# Altium schematic sheet Stepper Motor3.SchDoc
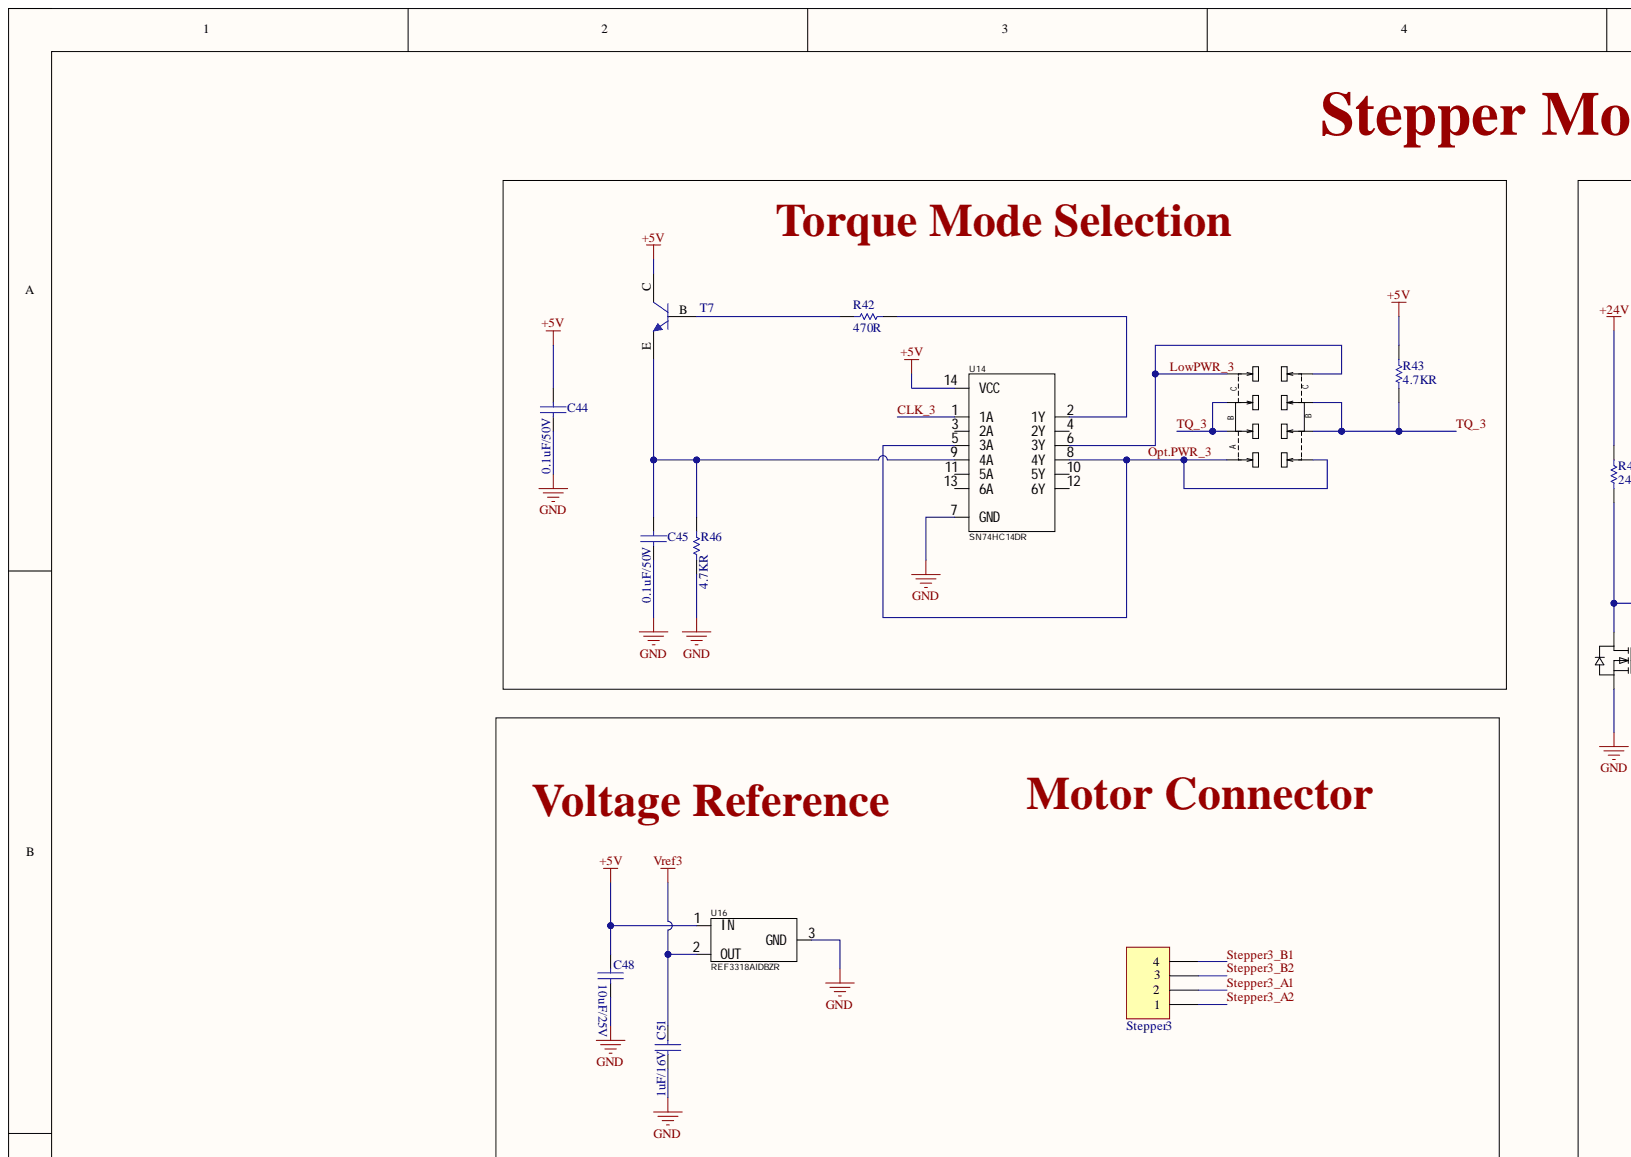
[diagram p0: Stepper Motor3.SchDoc, top left]
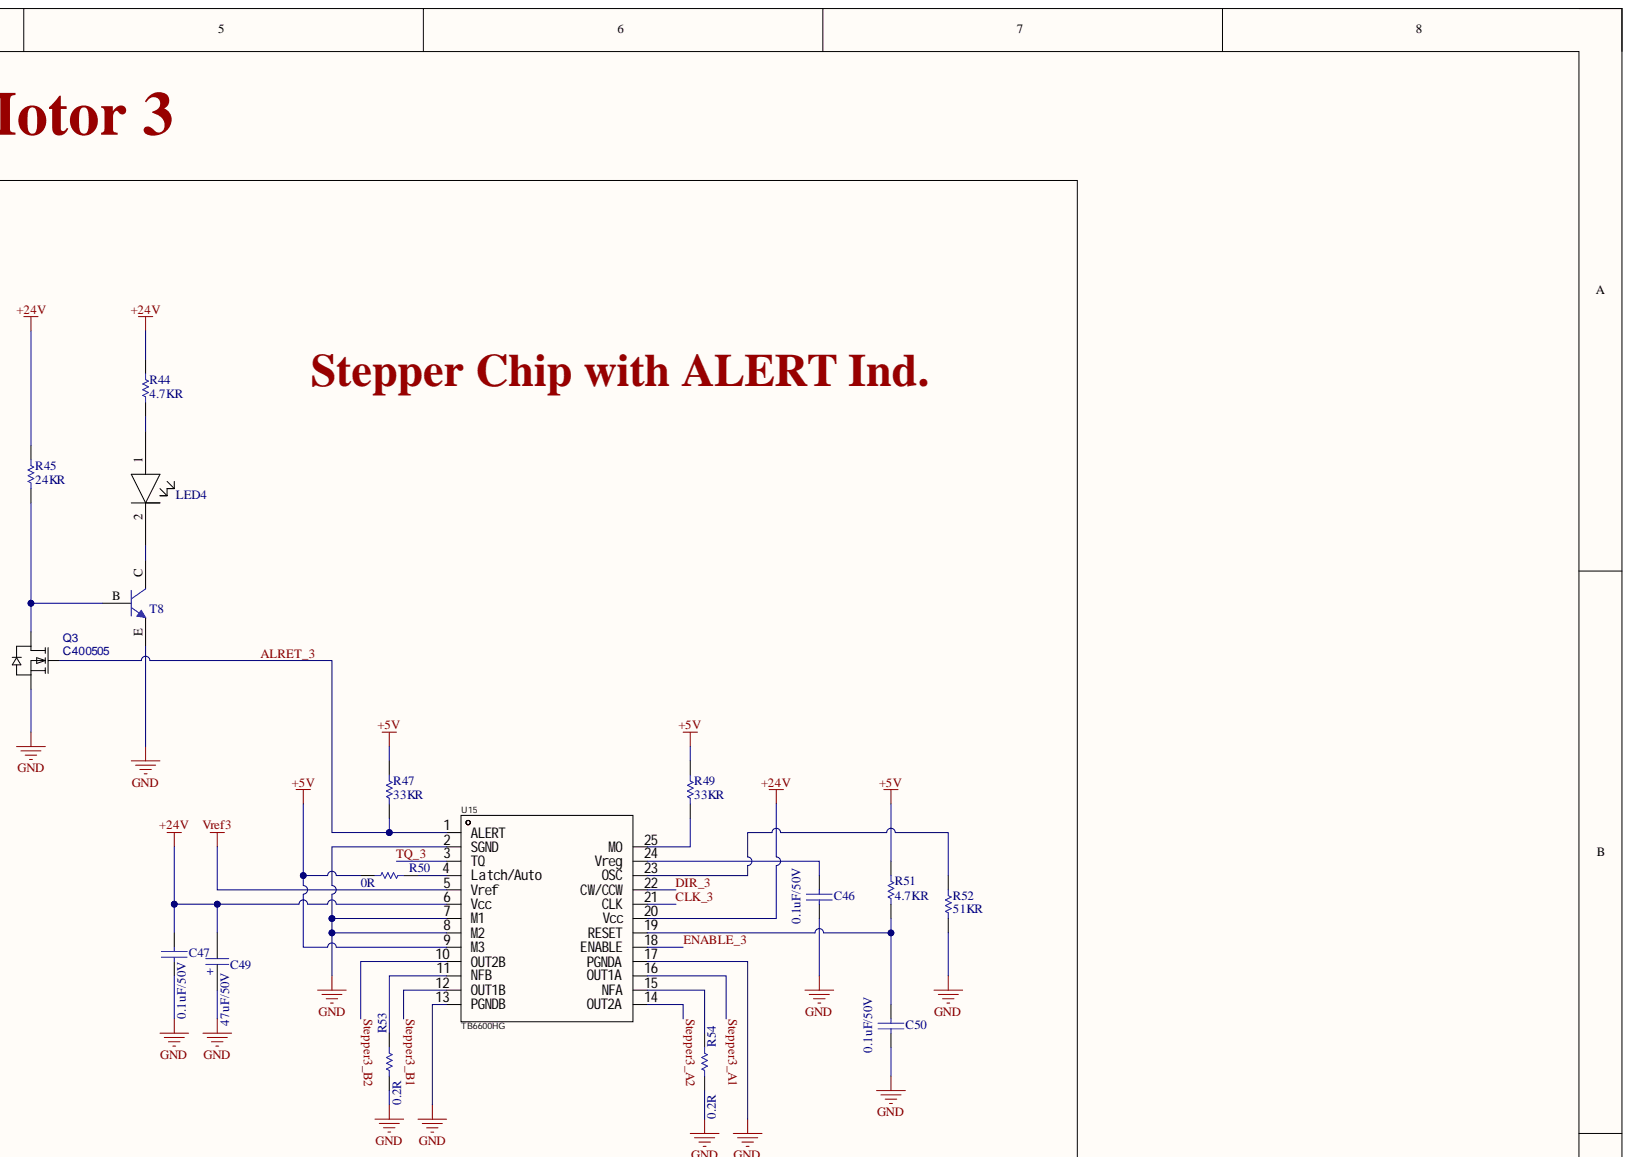
[diagram p1: Stepper Motor3.SchDoc, top right]
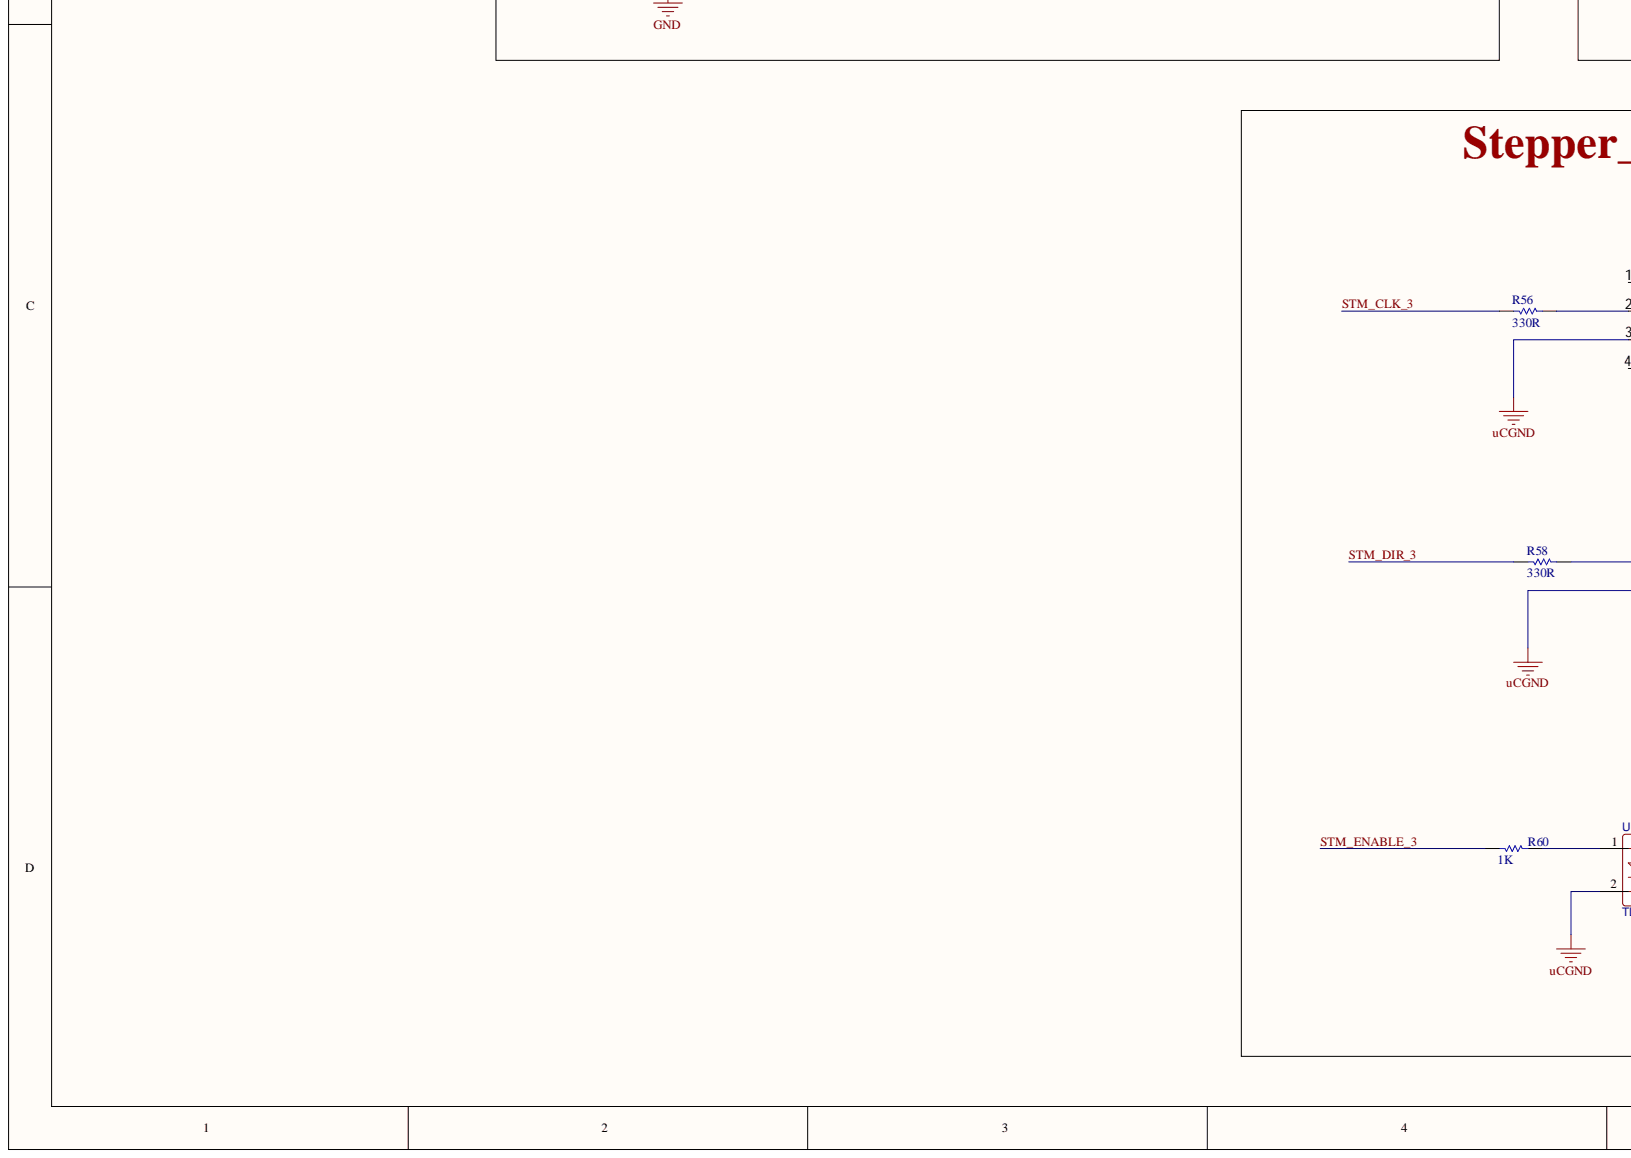
[diagram p2: Stepper Motor3.SchDoc, bottom left]
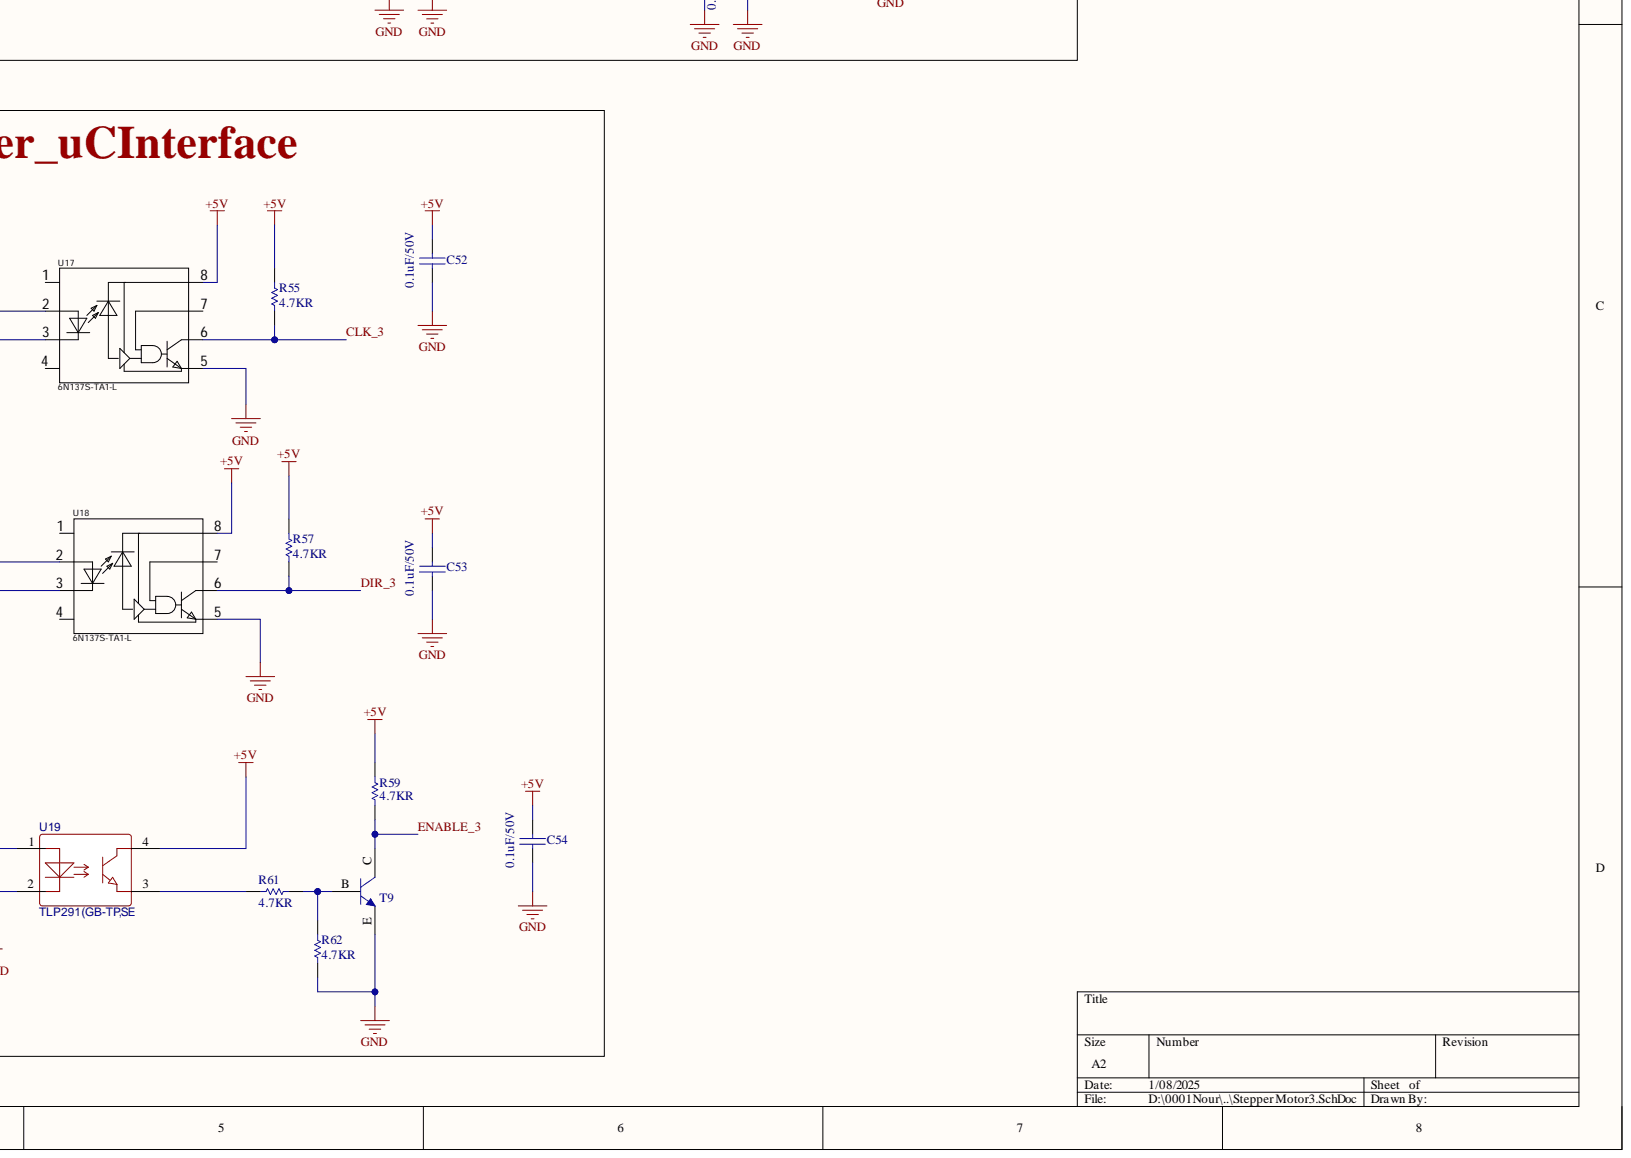
[diagram p3: Stepper Motor3.SchDoc, bottom right]

## Parts
C44  0.1uF/50V  [PCBComponent_1]
C45  0.1uF/50V  [PCBComponent_1]
C46  0.1uF/50V  [PCBComponent_1]
C47  0.1uF/50V  [PCBComponent_1]
C48  10uF/25V  [PCBComponent_1]
C49  47uF/50V  [CAPLEAD_D6.3mm]
C50  0.1uF/50V  [PCBComponent_1]
C51  1uF/16V  [PCBComponent_1]
C52  0.1uF/50V  [PCBComponent_1]
C53  0.1uF/50V  [PCBComponent_1]
C54  0.1uF/50V  [PCBComponent_1]
LED4  1206 LED  [1206 LED]  (value not drawn)
Q3  C400505  [SOT-23-3_L2.9-W1.3-P1.90-LS2.4-BR]
R42  470R  [RESC1608X06N]
R43  4.7KR  [R0402]
R44  4.7KR  [R0402]
R45  24KR  [RESC1608X06N]
R46  4.7KR  [R0402]
R47  33KR  [RESC1608X06N]
R49  33KR  [RESC1608X06N]
R50  0R  [RESC1608X06N]
R51  4.7KR  [R0402]
R52  51KR  [R0402]
R53  0.2R  [RESC6431X12N]
R54  0.2R  [RESC6431X12N]
R55  4.7KR  [R0402]
R56  330R  [R0402]
R57  4.7KR  [R0402]
R58  330R  [R0402]
R59  4.7KR  [R0402]
R60  1K  [R0402]
R61  4.7KR  [R0402]
R62  4.7KR  [R0402]
Stepper3  Header 4 Pin Polar  [PCBComponent_1]  (value not drawn)
SW3  MST23D19G2  [SW-SMD_MST23D19G2]  (value not drawn)
T7  MMBT3904 Transistor  [MMBT3904]  (value not drawn)
T8  MMBT3904 Transistor  [MMBT3904]  (value not drawn)
T9  MMBT3904 Transistor  [MMBT3904]  (value not drawn)
U14  SN74HC14DR  [SOIC-14_L8.7-W3.9-P1.27-LS6.0-BL]
U15  TB6600HG  [HZIP25-P-1.00F]
U16  REF3318AIDBZR  [SOT-23-3_L2.9-W1.3-P1.90-LS2.4-BR]
U17  6N137S-TA1-L  [SOP-8_L9.8-W6.6-P2.54-LS10.3-BL]
U18  6N137S-TA1-L  [SOP-8_L9.8-W6.6-P2.54-LS10.3-BL]
U19  TLP291(GB-TP,SE  [SOP-4-1.27mm]

## Nets
+5V: C44.2 C48.2 C52.2 C53.2 C54.2 R43.1 R47.2 R49.2 R50.2 R51.1 R55.1 R57.1 R59.1 T7.C U14.14(VCC) U15.9(M3) U16.1 U17.8 U18.8 U19.4
+24V: C47.2 C49.2 R44.1 R45.2 U15.6(Vcc) U15.20(Vcc)
GND: C44.1 C45.1 C46.1 C47.1 C48.1 C49.1 C50.1 C51.1 C52.1 C53.1 C54.1 Q3.2 R46.2 R52.2 R53.1 R54.1 R62.2 T8.E T9.E U14.7(GND) U15.2(SGND) U15.7(M1) U15.8(M2) U15.13(PGNDB) U15.17(PGNDA) U16.3 U17.5 U18.5
uCGND: U17.3 U18.3 U19.2
Vref3: C51.2 U15.5(Vref) U16.2
ALRET_3: Q3.1 R47.1 U15.1(ALERT)
CLK_3: R55.2 U14.1(1A) U15.21(CLK) U17.6
DIR_3: R57.2 U15.22(CW/CCW) U18.6
ENABLE_3: R59.2 T9.C U15.18(ENABLE)
LowPWR_3: SW3.4 SW3.5 U14.6(3Y)
Opt.PWR_3: SW3.1 SW3.8 U14.5(3A) U14.8(4Y)
Stepper3_A1: Stepper3.2 U15.16(OUT1A)
Stepper3_A2: Stepper3.1 U15.14(OUT2A)
Stepper3_B1: Stepper3.4 U15.12(OUT1B)
Stepper3_B2: Stepper3.3 U15.10(OUT2B)
STM_CLK_3: R56.2
STM_DIR_3: R58.2
STM_ENABLE_3: R60.2
TQ_3: R43.2 SW3.2 SW3.3 SW3.6 SW3.7 U15.3(TQ)
NetC45_2: C45.2 R46.1 T7.E U14.9(4A)
NetC46_2: C46.2 U15.24(Vreg)
NetC50_2: C50.2 R51.2 U15.19(RESET)
NetLED4_1: LED4.1 R44.2
NetLED4_2: LED4.2 T8.C
NetQ3_3: Q3.3 R45.1 T8.B
NetR42_1: R42.1 U14.2(1Y)
NetR42_2: R42.2 T7.B
NetR49_1: R49.1 U15.25(MO)
NetR50_1: R50.1 U15.4(Latch/Auto)
NetR52_1: R52.1 U15.23(OSC)
NetR53_2: R53.2 U15.11(NFB)
NetR54_2: R54.2 U15.15(NFA)
NetR56_1: R56.1 U17.2
NetR58_1: R58.1 U18.2
NetR60_1: R60.1 U19.1
NetR61_1: R61.1 R62.1 T9.B
NetR61_2: R61.2 U19.3

Not connected: U14 3(2A) 4(2Y) 10(5Y) 11(5A) 12(6Y) 13(6A); U17 1 4 7; U18 1 4 7

## Library data of the parts
C44-C47 C50 C52-C54 = 0603 Capacitor: C1591; Component Kind: Standard; DataSheet Link: https://eu.mouser.com/datasheet/2/400/lcc_automotive_general_en-843974.pdf; Library Name: 0603 Capacitor.IntLib; Mouser Link: https://eu.mouser.com/ProductDetail/TDK/CGA1A2X7R1H331M030BA?qs=sGAEpiMZZMs0AnBnWHyRQL8L3bkL%252bvtyS9XpSB1mk7rVV5K8%252bbxEeg%3d%3d; Created By: NourAldin
C48 = 0603 Capacitor: C96446; Component Kind: Standard; DataSheet Link: https://eu.mouser.com/datasheet/2/400/lcc_automotive_general_en-843974.pdf; Library Name: 0603 Capacitor.IntLib; Mouser Link: https://eu.mouser.com/ProductDetail/TDK/CGA1A2X7R1H331M030BA?qs=sGAEpiMZZMs0AnBnWHyRQL8L3bkL%252bvtyS9XpSB1mk7rVV5K8%252bbxEeg%3d%3d; Created By: NourAldin
C49 = A759EQ475M2AAAE160: C45100; Manufacturer: KEMET; Category: Polymer Capacitors; Component Kind: Standard; ComponentLink1Description: http://www.kemet.com/docfinder?Partnumber=A759EQ475M2AAAE160&src-supplier=Digikey; ComponentLink1URL: http://www.kemet.com/docfinder?Partnumber=A759EQ475M2AAAE160&src-supplier=Digikey; ComponentLink2Description: http://www.farnell.com/datasheets/2095614.pdf?src-supplier=Element14; ComponentLink2URL: http://www.farnell.com/datasheets/2095614.pdf?src-supplier=Element14; Library Name: GlobalLib.IntLib; Manufacturer Part Number: A759EQ475M2AAAE160; Pricing 1: 1=0.97, 10=0.73, 50=0.73, 100=0.546, 1000=0.384, 10000=0.326 (USD); RoHS: Yes; Stock 1: 17219; Supplier 1: Mouser; Supplier Part Number 1: 80-A759EQ475M2AAE160
C51 = 0603 Capacitor: C1592; Component Kind: Standard; DataSheet Link: https://eu.mouser.com/datasheet/2/400/lcc_automotive_general_en-843974.pdf; Library Name: 0603 Capacitor.IntLib; Mouser Link: https://eu.mouser.com/ProductDetail/TDK/CGA1A2X7R1H331M030BA?qs=sGAEpiMZZMs0AnBnWHyRQL8L3bkL%252bvtyS9XpSB1mk7rVV5K8%252bbxEeg%3d%3d; Created By: NourAldin
Q3 = BSS138_MOSFET: Manufacturer: YANGJIE(扬杰); Manufacturer Part: BSS138; Contributor: LCSC; Component Kind: Standard; Description: C400505; JLCPCB Part Class: Extended Part; spicePre: Q; spiceSymbolName: BSS138_C400505; Supplier: LCSC; Supplier Part: C400505; Library Name: DIVERSE.IntLib
R42 R45 R47 R49 R50 = 0603 Resistor: Component Kind: Standard; DataSheet Link: https://eu.mouser.com/datasheet/2/315/AOA0000C307-1149632.pdf; Description: 0603 Resistor; Library Name: 0603 Resistor.IntLib; Mouser Link: https://eu.mouser.com/ProductDetail/Panasonic/ERA-3AHD180V?qs=sGAEpiMZZMvdGkrng054t5mej2KPdPuFSlDBd1tSiF0%3d; Created By: NourAldin
R43 R44 R46 R51 R52 R55 R57 R59 R61 R62 = 0402 Resistor: C105871; Component Kind: Standard; Library Name: DIVERSE.IntLib
R53 R54 = 2512 Resistor: C2903473; Component Kind: Standard; DataSheet Link: https://eu.mouser.com/datasheet/2/54/ss2h-2512-1302652.pdf; Library Name: 2512 Resistor.IntLib; Mouser Link: https://eu.mouser.com/ProductDetail/Bourns/CSS2H-2512K-2L00F?qs=sGAEpiMZZMukHu%252bjC5l7YewuupLM8jZrvWs15Y6t0rY%3D&fbclid=IwAR0ZD_uc1Y03YG_KyYG8NxrpBzYgFzVeevvjxrlqtGWJ1HKz-jrYfqlFWCw; Created By: NourAldin
R56 R58 = 0402 Resistor: C105875; Component Kind: Standard; Library Name: DIVERSE.IntLib
R60 = 0402 Resistor: C106235; Component Kind: Standard; Library Name: DIVERSE.IntLib
SW3 = MST23D19G2: Manufacturer: SHOU HAN(首韩); Add into BOM: yes; Circuit: 双刀三掷; Current Rating (DC): 100mA; Mechanical Life: 1万次; Pin Style: SMDSplicing; Switch Height: 3.5mm; Switch Length: 12.95mm; Switch Width: 3.55mm; Voltage Rating (DC): 12V; Actuator Color: -; Actuator Style: -; Component Kind: Standard; Convert to PCB: yes; Current Rating (AC): -; Datasheet: https://atta.szlcsc.com/upload/public/pdf/source/20190925/C431545_A88710AC241B275593C257CF6D595AD7.pdf; Device: MST23D19G2; JLCPCB Part Class: Extended Part; LCSC Part Name: 三档立式贴片 8脚拨动 柄长2.0MM; Library Name: LIB.SchLib; Manufacturer Part: MST23D19G2; Mounting Style: Brick nogging; Operating Temperature: -25℃~+70℃; Supplier: LCSC; Supplier Footprint: SMD; Supplier Part: C431545; Symbol: MST23D19G2; Voltage Rating (AC): -
U14 = SN74HC14DR: C6820; Manufacturer: TI(德州仪器); Add into BOM: yes; High level range (VIH): 1.5V~4.2V; Input Type: Schmitt Trigger; Low level range (VIL): 300mV~1.2V; Max Propagation Delay: 21ns@6V,50pF; Number of Circuits: 6; Number of Inputs: 1; Sink Current: 5.2mA; Supply Current (Max): 2uA; Supply Voltage(Single): 2V~6V; Component Kind: Standard; Convert to PCB: yes; Datasheet: https://www.ti.com/cn/lit/gpn/sn74hc14; Device: SN74HC14DR; JLCPCB Part Class: Extended Part; LCSC Part Name: 六路施密特触发反相器; Library Name: LIB.SchLib; Manufacturer Part: SN74HC14DR; Operating Temperature: -40℃~+85℃; Series: 74HCSeries; Source Current: 5.2mA; Supplier: LCSC; Supplier Footprint: SOIC-14; Supplier Part: C6820; Symbol: SN74HC14DR
U15 = TB6600HG: C66042; Manufacturer: TOSHIBA(东芝); Add into BOM: yes; Component Kind: Standard; Convert to PCB: yes; Datasheet: https://atta.szlcsc.com/upload/public/pdf/source/20170511/1494500127812.pdf; Device: TB6600HG; JLCPCB Part Class: Extended Part; LCSC Part Name: 4.5A 42V电机驱动芯片; Library Name: LIB.SchLib; Manufacturer Part: TB6600HG; Supplier: LCSC; Supplier Footprint: HZIP-25-P-1mm; Supplier Part: C66042; Symbol: TB6600HG
U16 = REF3318AIDBZR: C115877; Manufacturer: TI(德州仪器); Add into BOM: yes; Input Voltage: 2V~5.5V; Noise - 1/10Hz to 10Hz: 50uVp-p; Output Current: 5mA; Output Type: Fixed; Output Voltage: 1.8V; Reference Type: series connection; Supply Current: 8.5uA; Temperature Coefficient: 30ppm/℃; Tolerance: ±0.15%; Component Kind: Standard; Convert to PCB: yes; Datasheet: https://www.ti.com/cn/lit/gpn/ref3318; Device: REF3318AIDBZR; JLCPCB Part Class: Extended Part; LCSC Part Name: REF3318AIDBZR; Library Name: LIB.SchLib; Manufacturer Part: REF3318AIDBZR; Noise - 10Hz to 10kHz: -; Operating Temperature: -40℃~+125℃@(Ta); Supplier: LCSC; Supplier Footprint: SOT-23; Supplier Part: C115877; Symbol: REF3318AIDBZR
U17 U18 = 6N137S-TA1-L: C92651; Manufacturer: LITEON(光宝); Add into BOM: yes; Common Mode Transient Immunity (CMTI): 10kV/us; Data Rate: 15Mbit/s; Input Type: DC; Number of Channels: 1; Propagation Delay tpLH/tpHL: 32ns；40ns; Supply Voltage: 4.5V~5.5V; Component Kind: Standard; Convert to PCB: yes; Datasheet: https://atta.szlcsc.com/upload/public/pdf/source/20191018/C92651_D1F9F6F1F10C7EC7C3739E5ECB700036.pdf; Device: 6N137S-TA1-L; JLCPCB Part Class: Extended Part; LCSC Part Name: DC输入 1通道; Library Name: LIB.SchLib; Manufacturer Part: 6N137S-TA1-L; Operating Temperature: -40℃~+85℃; Supplier: LCSC; Supplier Footprint: SOP-8-2.54mm; Supplier Part: C92651; Symbol: 6N137S-TA1
U19 = TLP291(GB-TP,SE(T: C55144; BOM_Manufacturer: TOSHIBA(东芝); BOM_JLCPCB Part Class: Extended Part; BOM_Supplier: LCSC; Component Kind: Standard; LCSC Link: https://www.lcsc.com/product-detail/Optocouplers-Phototransistor-Output_TOSHIBA-TLP291-GB-TP-SE_C55144.html; Library Name: CO2_Lib.IntLib; Manufacturer Part Number: TLP291(GB-TP,SE; spiceSymbolName: TLP291(GB-TP,SE(T; Supplier Part Number 2: C55144
LED4 = 1206 LED: Component Kind: Standard; Description: 1206 LED; Library Name: 1206 LED.IntLib; Created By: NourAldin
Stepper3 = Header 4 Pin Polar: Component Kind: Standard; Description: Header 4 Pin Polar; Library Name: Header 4 Pin Polar.IntLib
T7-T9 = MMBT3904 Transistor: Component Kind: Standard; Description: MMBT3904 Transistor; Library Name: MMBT3904 Transistor.IntLib; Created By: NourAldin
